# Altium schematic sheet LT6911C_V10.SchDoc
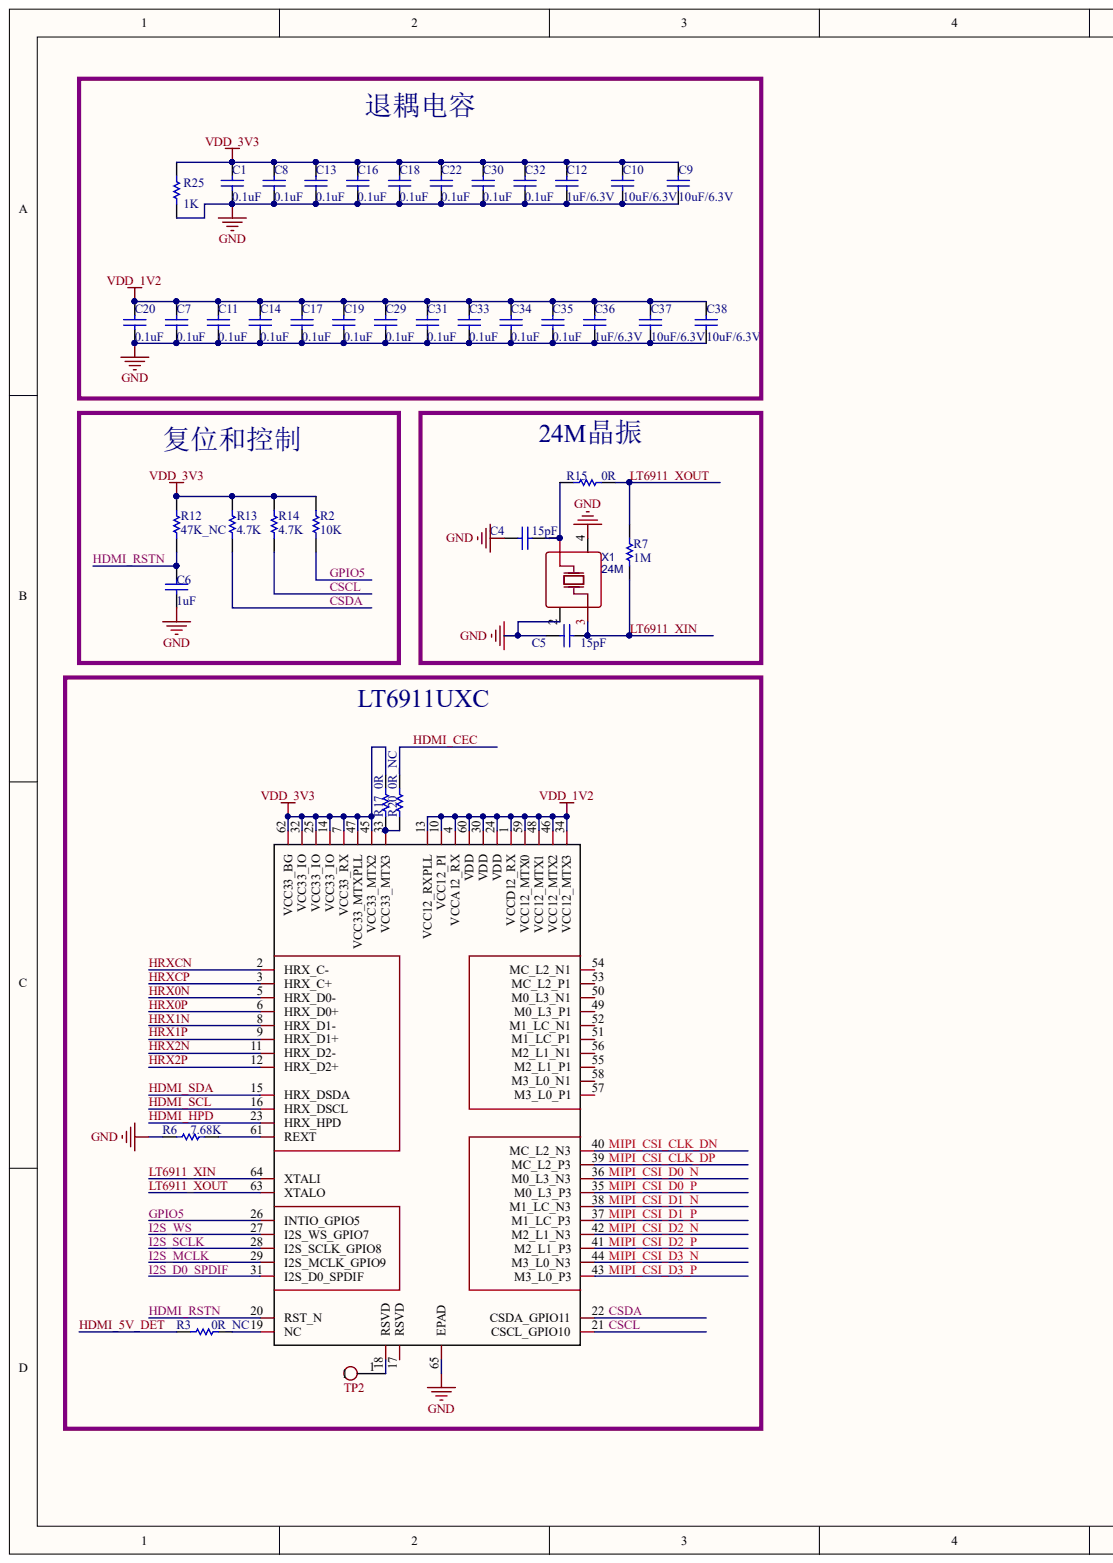
[diagram p0: LT6911C_V10.SchDoc, left]
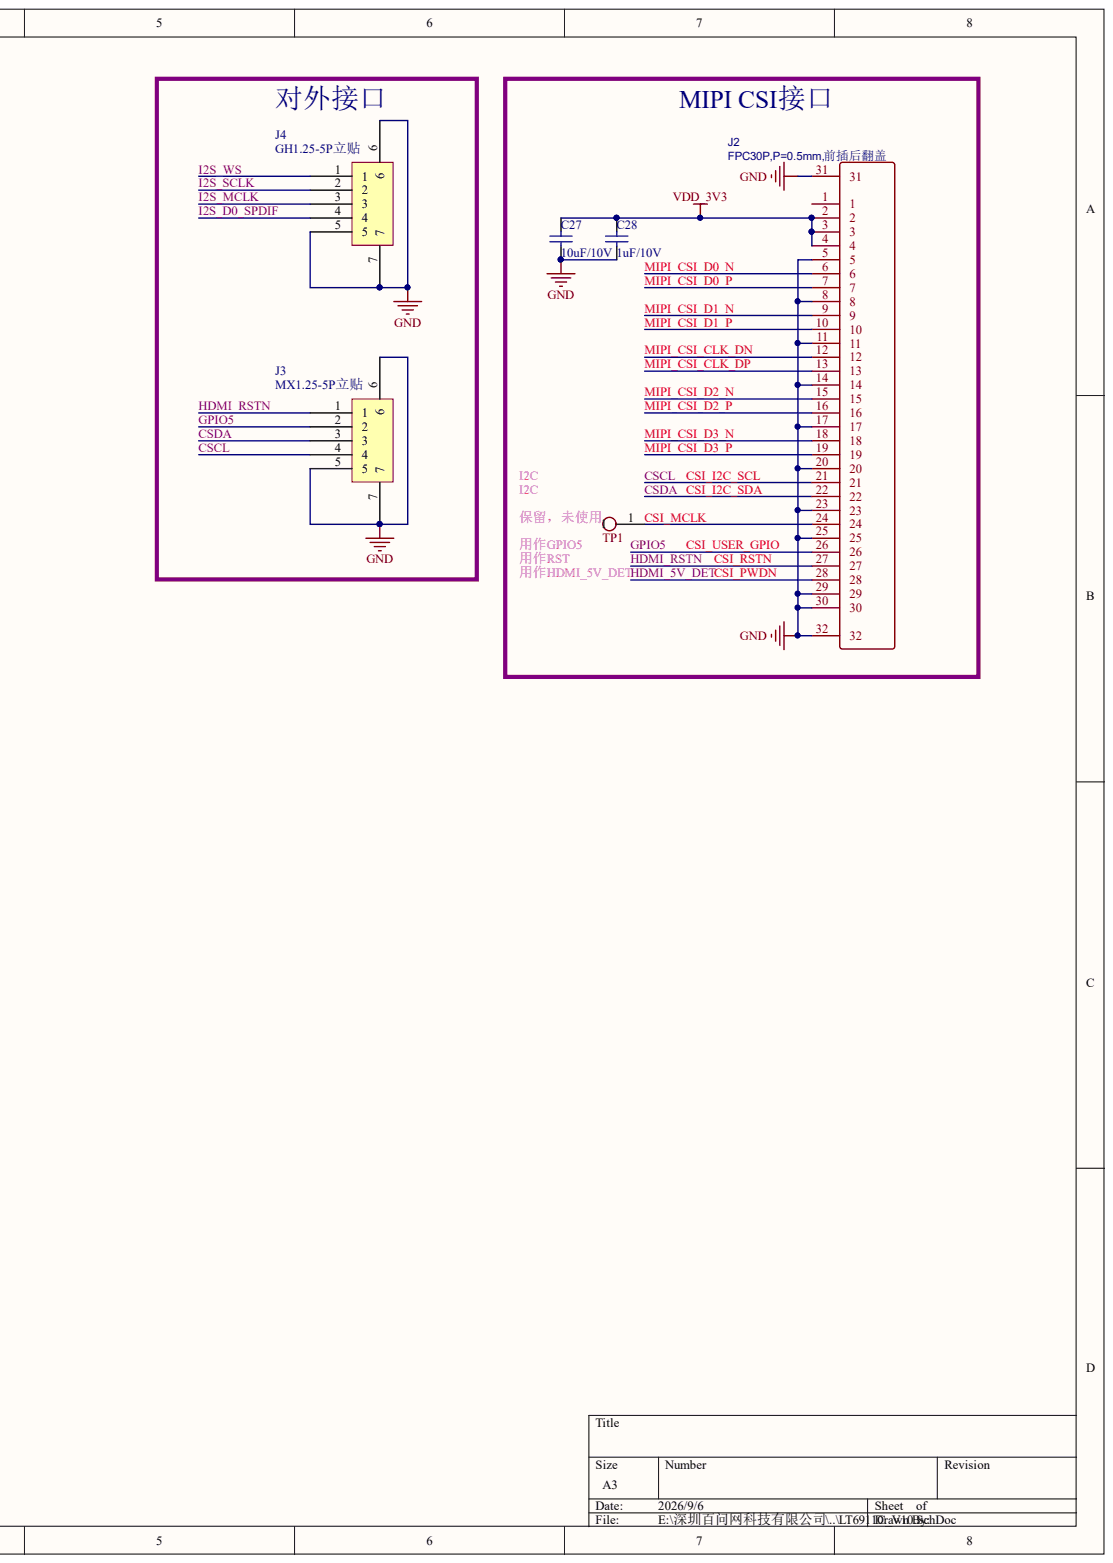
[diagram p1: LT6911C_V10.SchDoc, right]

## Parts
C1  0.1uF  [0201_CAP_SMT]
C4  15pF  [0201_CAP_SMT]
C5  15pF  [0201_CAP_SMT]
C6  1uF  [0201_CAP_SMT]
C7  0.1uF  [0201_CAP_SMT]
C8  0.1uF  [0201_CAP_SMT]
C9  10uF/6.3V  [0402]
C10  10uF/6.3V  [0402]
C11  0.1uF  [0201_CAP_SMT]
C12  1uF/6.3V  [0201_CAP_SMT]
C13  0.1uF  [0201_CAP_SMT]
C14  0.1uF  [0201_CAP_SMT]
C16  0.1uF  [0201_CAP_SMT]
C17  0.1uF  [0201_CAP_SMT]
C18  0.1uF  [0201_CAP_SMT]
C19  0.1uF  [0201_CAP_SMT]
C20  0.1uF  [0201_CAP_SMT]
C22  0.1uF  [0201_CAP_SMT]
C27  10uF/10V  [0402]
C28  1uF/10V  [0201_CAP_SMT]
C29  0.1uF  [0201_CAP_SMT]
C30  0.1uF  [0201_CAP_SMT]
C31  0.1uF  [0201_CAP_SMT]
C32  0.1uF  [0201_CAP_SMT]
C33  0.1uF  [0201_CAP_SMT]
C34  0.1uF  [0201_CAP_SMT]
C35  0.1uF  [0201_CAP_SMT]
C36  1uF/6.3V  [0201_CAP_SMT]
C37  10uF/6.3V  [0402]
C38  10uF/6.3V  [0402]
J2  FPC30P,P=0.5mm,前插后翻盖  [FPC-SMD_30P-P0.50_XUNPU_FPC-05F-30PH20]
J3  MX1.25-5P立贴  [CONN-SMD_5P-P1.25_MEGASTAR_ZX-MX1.25-5PLT]
J4  GH1.25-5P立贴  [CONN-SMD_5P-P1.25_XUNPU_WAFER-GH1.25-5PLB]
R2  10K  [0201_RES_SMT]
R3  0R_NC  [0201_RES_SMT]
R6  7.68K  [0201_RES_SMT]
R7  1M  [0201_RES_SMT]
R12  47K_NC  [0201_RES_SMT]
R13  4.7K  [0201_RES_SMT]
R14  4.7K  [0201_RES_SMT]
R15  0R  [0201_RES_SMT]
R17  0R  [0201_RES_SMT]
R20  0R_NC  [0201_RES_SMT]
R25  1K  [0603]
TP1  Value  [TP_1MM]  (value not drawn)
TP2  Value  [TP_1MM]  (value not drawn)
U1  LT6911UXC  [QFN-64_L7.5-W7.5-P0.4-LT-EP6.2]
X1  24M  [CRYSTAL-SMD_4P-L2.0-W1.6-BL-A]

## Nets
GND: C1.1 C4.1 C5.1 C6.1 C7.1 C8.1 C9.1 C10.1 C11.1 C12.1 C13.1 C14.1 C16.1 C17.1 C18.1 C19.1 C20.1 C22.1 C27.1 C28.1 C29.1 C30.1 C31.1 C32.1 C33.1 C34.1 C35.1 C36.1 C37.1 C38.1 J2.5 J2.8 J2.11 J2.14 J2.17 J2.20 J2.23 J2.25 J2.29 J2.30 J2.31 J2.32 J3.5 J3.6 J3.7 J4.5 J4.6 J4.7 R6.2 R25.2 U1.65(EPAD) X1.2 X1.4
VDD_1V2: C7.2 C11.2 C14.2 C17.2 C19.2 C20.2 C29.2 C31.2 C33.2 C34.2 C35.2 C36.2 C37.2 C38.2 U1.1(VCCD12_RX) U1.4(VCCA12_RX) U1.10(VCC12_PI) U1.13(VCC12_RXPLL) U1.24(VDD) U1.30(VDD) U1.34(VCC12_MTX3) U1.46(VCC12_MTX2) U1.48(VCC12_MTX1) U1.59(VCC12_MTX0) U1.60(VDD)
VDD_3V3: C1.2 C8.2 C9.2 C10.2 C12.2 C13.2 C16.2 C18.2 C22.2 C27.2 C28.2 C30.2 C32.2 J2.2 J2.3 J2.4 R2.1 R12.1 R13.1 R14.1 R17.2 R25.1 U1.7(VCC33_RX) U1.14(VCC33_IO) U1.25(VCC33_IO) U1.32(VCC33_IO) U1.45(VCC33_MTX2) U1.47(VCC33_MTXPLL) U1.62(VCC33_BG)
CSCL: J2.21 J3.4 R14.2 U1.21(CSCL_GPIO10)
CSDA: J2.22 J3.3 R13.2 U1.22(CSDA_GPIO11)
CSI_MCLK: J2.24 TP1.1
CSI_PWDN: J2.28 R3.1
CSI_RSTN: C6.2 J2.27 J3.1 R12.2 U1.20(RST_N)
CSI_USER_GPIO: J2.26 J3.2 R2.2 U1.26(INTIO_GPIO5)
HDMI_CEC: R20.2
HDMI_HPD: U1.23(HRX_HPD)
HDMI_SCL: U1.16(HRX_DSCL)
HDMI_SDA: U1.15(HRX_DSDA)
HRX0N: U1.5(HRX_D0-)
HRX0P: U1.6(HRX_D0+)
HRX1N: U1.8(HRX_D1-)
HRX1P: U1.9(HRX_D1+)
HRX2N: U1.11(HRX_D2-)
HRX2P: U1.12(HRX_D2+)
HRXCN: U1.2(HRX_C-)
HRXCP: U1.3(HRX_C+)
I2S_D0_SPDIF: J4.4 U1.31(I2S_D0_SPDIF)
I2S_MCLK: J4.3 U1.29(I2S_MCLK_GPIO9)
I2S_SCLK: J4.2 U1.28(I2S_SCLK_GPIO8)
I2S_WS: J4.1 U1.27(I2S_WS_GPIO7)
LT6911_XIN: C5.2 R7.2 U1.64(XTALI) X1.3
LT6911_XOUT: R7.1 R15.2 U1.63(XTALO)
MIPI_CSI_CLK_DN: J2.12 U1.40(MC_L2_N3)
MIPI_CSI_CLK_DP: J2.13 U1.39(MC_L2_P3)
MIPI_CSI_D0_N: J2.6 U1.36(M0_L3_N3)
MIPI_CSI_D0_P: J2.7 U1.35(M0_L3_P3)
MIPI_CSI_D1_N: J2.9 U1.38(M1_LC_N3)
MIPI_CSI_D1_P: J2.10 U1.37(M1_LC_P3)
MIPI_CSI_D2_N: J2.15 U1.42(M2_L1_N3)
MIPI_CSI_D2_P: J2.16 U1.41(M2_L1_P3)
MIPI_CSI_D3_N: J2.18 U1.44(M3_L0_N3)
MIPI_CSI_D3_P: J2.19 U1.43(M3_L0_P3)
NetC4_2: C4.2 R15.1 X1.1
NetR3_2: R3.2 U1.19(NC)
NetR6_1: R6.1 U1.61(REXT)
NetR17_1: R17.1 R20.1 U1.33(VCC33_MTX3)
NetTP2_1: TP2.1 U1.18(RSVD)

Not connected: J2 1; U1 17(RSVD) 49(M0_L3_P1) 50(M0_L3_N1) 51(M1_LC_P1) 52(M1_LC_N1) 53(MC_L2_P1) 54(MC_L2_N1) 55(M2_L1_P1) 56(M2_L1_N1) 57(M3_L0_P1) 58(M3_L0_N1)

## Library data of the parts
C1 C4-C14 C16-C20 C22 C27-C38 = Cap: Capacitor; PartNumber: CAP100NF; Component Kind: Standard; LatestRevisionDate: 17-Jul-2002; LatestRevisionNote: Re-released for DXP Platform.; Library Name: Miscellaneous Devices.IntLib; PackageReference: 0402; Published: 8-Jun-2000; Signal Integrity: Cap; Simulation: CAP; Publisher: Altium Limited
  C1 C7 C8 C11 C13 C14 C16-C20 C22 C29-C35: Value: 0.1uF
  C4 C5: Value: 15pF
  C6: Value: 1uF
  C9 C10 C37 C38: Value: 10uF/6.3V
  C12 C36: Value: 1uF/6.3V
  C27: Value: 10uF/10V
  C28: Value: 1uF/10V
J2 = AFC01-S30FCA-00: BOM_Manufacturer: JUSHUO; Contributor: LCSC; BOM_JLCPCB Part Class: Extended Part; BOM_Supplier: LCSC; BOM_Supplier Part: https://item.taobao.com/item.htm?id=666565678497&; Component Kind: Standard; JLC NO: C2856833; spicePre: F
J3 J4 = 1.25T_5P: Component Kind: Standard; Library Name: HM2.SchLib
  J3: JLC NO: C7430476
R2 R3 R6 R7 R12-R15 R17 R20 R25 = Res1: Resistor; PartNumber: RES2.2K; Component Kind: Standard; LatestRevisionDate: 17-Jul-2002; LatestRevisionNote: Re-released for DXP Platform.; Library Name: Miscellaneous Devices.IntLib; PackageReference: 0402; Published: 8-Jun-2000; Signal Integrity: Res; Simulation: RESISTOR; Publisher: Altium Limited
  R2: Value: 10K
  R3 R20: Value: 0R_NC
  R6: Value: 7.68K
  R7: Value: 1M
  R12: Value: 47K_NC
  R13 R14: Value: 4.7K
  R15 R17: Value: 0R
  R25: Value: 1K
TP1 TP2 = TP: Component Kind: Standard; Library Name: HM2.SchLib
U1 = LT6911UXC: Add into BOM: yes; Name: #NAME?; Component Kind: Standard; Convert to PCB: yes; JLCPCB Part Class: Extended Part; Library Name: HM2.SchLib; PCB3D: QFN-64_L7.5-W7.5-P0.4-LT-EP6.2; Supplier: LCSC; Supplier Footprint: QFN-64-EP(7.5x7.5)
X1 = 25M: BOM_Manufacturer: TKD; Contributor: LCSC; nameAlias: Frequency; BOM_JLCPCB Part Class: Extended Part; BOM_Supplier: LCSC; Component Kind: Standard; Library Name: HM2.SchLib; spicePre: X
